# Altium schematic sheet Trigger.SchDoc(TR)
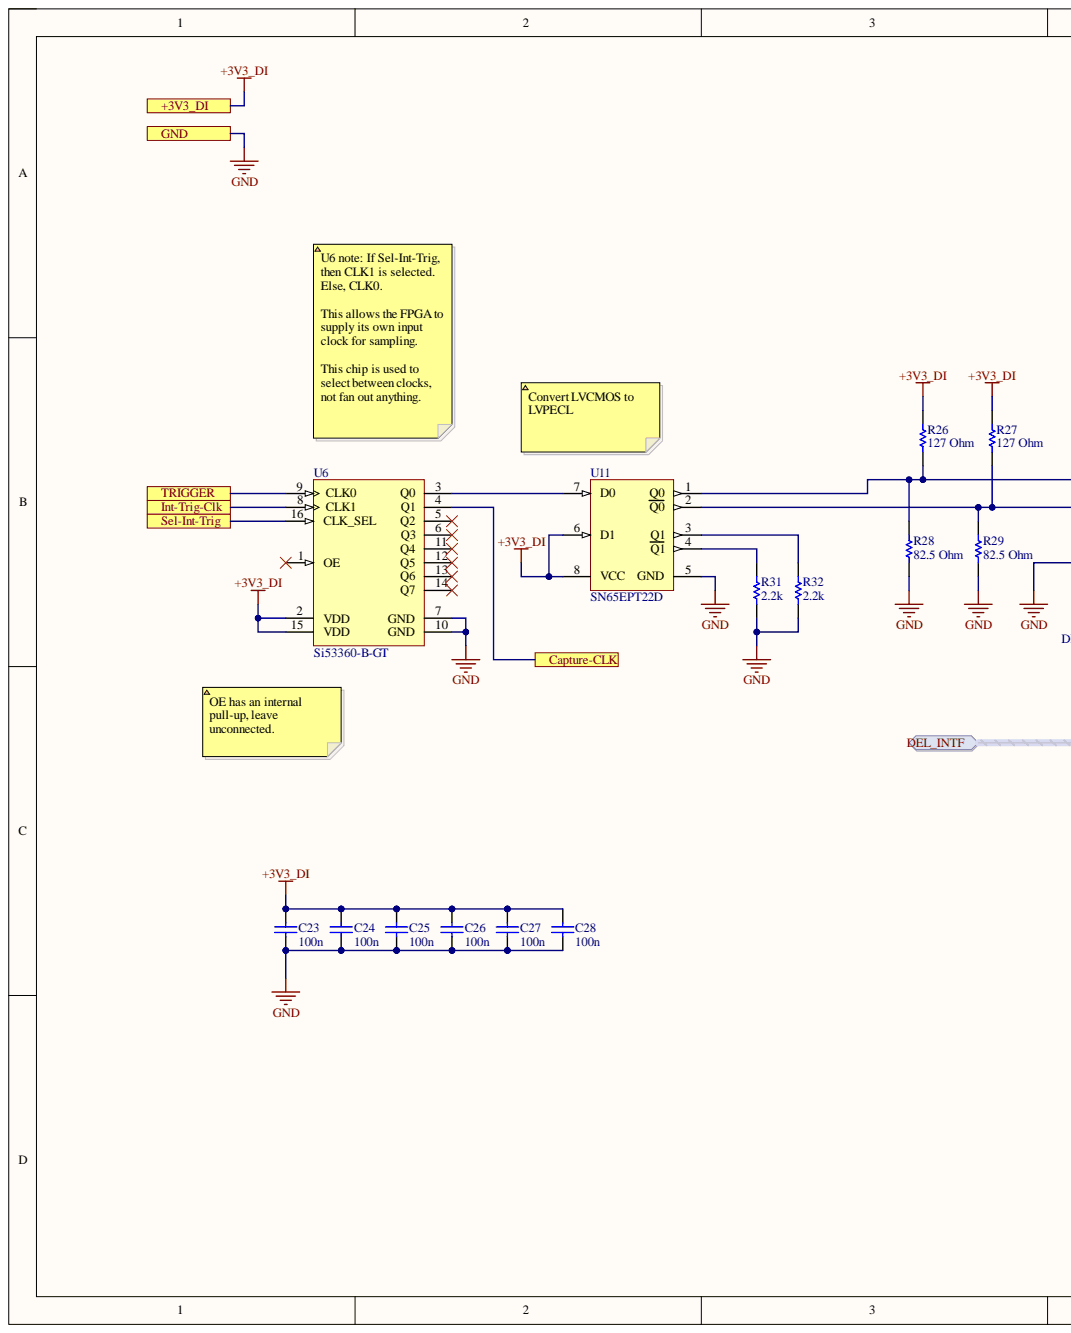
[diagram p0: Trigger.SchDoc, left]
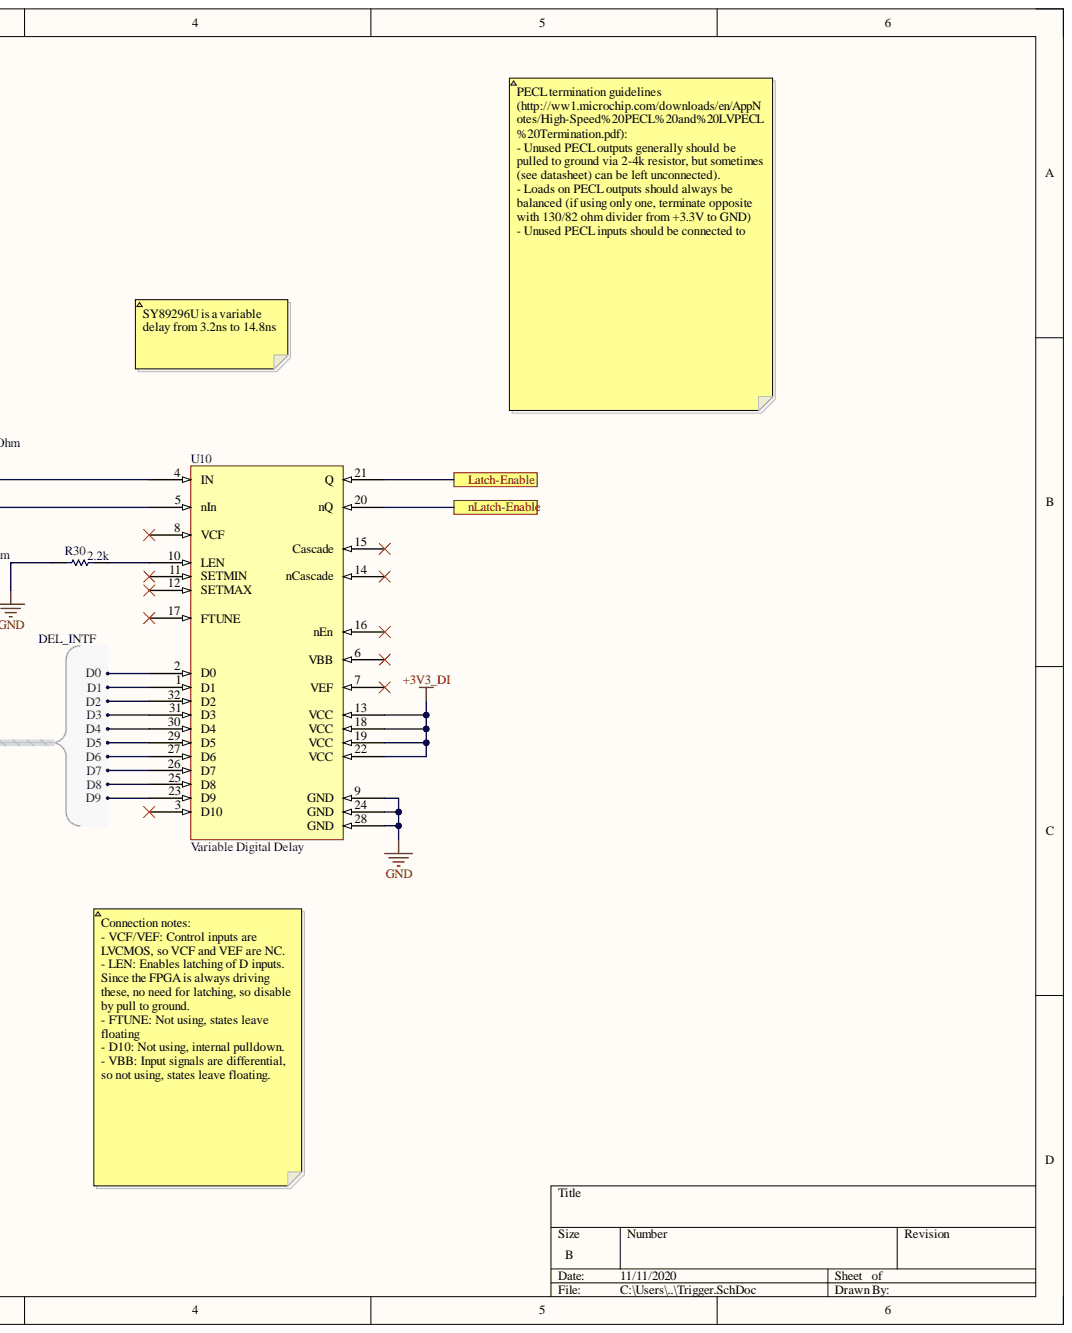
[diagram p1: Trigger.SchDoc, right]

## Parts
C23  value 100n  [CAPC2013X88X50NL20T25]  (hidden comment: C0805C104K5RACTU)
C24  value 100n  [CAPC2013X88X50NL20T25]  (hidden comment: C0805C104K5RACTU)
C25  value 100n  [CAPC2013X88X50NL20T25]  (hidden comment: C0805C104K5RACTU)
C26  value 100n  [CAPC2013X88X50NL20T25]  (hidden comment: C0805C104K5RACTU)
C27  value 100n  [CAPC2013X88X50NL20T25]  (hidden comment: C0805C104K5RACTU)
C28  value 100n  [CAPC2013X88X50NL20T25]  (hidden comment: C0805C104K5RACTU)
R26  127 Ohm  [RESC2013X50X30NL20T20]
R27  127 Ohm  [RESC2013X50X30NL20T20]
R28  82.5 Ohm  [RESC2013X50X30ML20T20]
R29  82.5 Ohm  [RESC2013X50X30ML20T20]
R30  2.2k  [RESC2013X50X30NL20T20]
R31  2.2k  [RESC2013X50X30NL20T20]
R32  2.2k  [RESC2013X50X30NL20T20]
U6  Si53360-B-GT  [SLAB-TSSOP-16_M]
U10  Variable Digital Delay  [CQFP80P1115X1115X120-32N]
U11  SN65EPT22D  [D0008A_M]

## Ports
+3V3_DI, Capture-CLK, DEL_INTF, DEL_INTF.D0, DEL_INTF.D1, DEL_INTF.D2, DEL_INTF.D3, DEL_INTF.D4, DEL_INTF.D5, DEL_INTF.D6, DEL_INTF.D7, DEL_INTF.D8, DEL_INTF.D9, GND, Int-Trig-Clk, Latch-Enable, nLatch-Enable, Sel-Int-Trig, TRIGGER

## Nets
+3V3_DI: C23.2 C24.2 C25.2 C26.2 C27.2 C28.2 R26.2 R27.2 U6.2(VDD) U6.15(VDD) U10.13(VCC) U10.18(VCC) U10.19(VCC) U10.22(VCC) U11.6(D1) U11.8(VCC) ; port +3V3_DI
Capture-CLK: U6.4(Q1) ; port Capture-CLK
GND: C23.1 C24.1 C25.1 C26.1 C27.1 C28.1 R28.1 R29.1 R30.1 R31.2 R32.2 U6.7(GND) U6.10(GND) U10.9(GND) U10.24(GND) U10.28(GND) U11.5(GND) ; port GND
Int-Trig-Clk: U6.8(CLK1) ; port Int-Trig-Clk
Latch-Enable: U10.21(Q) ; port Latch-Enable
nLatch-Enable: U10.20(nQ) ; port nLatch-Enable
Sel-Int-Trig: U6.16(CLK_SEL) ; port Sel-Int-Trig
TRIGGER: U6.9(CLK0) ; port TRIGGER
NetR26_1: R26.1 R28.2 U10.4(IN) U11.1(Q0)
NetR27_1: R27.1 R29.2 U10.5(nIn) U11.2(Q0)
NetR30_2: R30.2 U10.10(LEN)
NetR31_1: R31.1 U11.4(Q1)
NetR32_1: R32.1 U11.3(Q1)
NetU6_1: U6.1(OE)
NetU6_3: U6.3(Q0) U11.7(D0)
NetU6_5: U6.5(Q2)
NetU6_6: U6.6(Q3)
NetU6_11: U6.11(Q4)
NetU6_12: U6.12(Q5)
NetU6_13: U6.13(Q6)
NetU6_14: U6.14(Q7)
NetU10_1: U10.1(D1) ; port DEL_INTF
NetU10_2: U10.2(D0) ; port DEL_INTF
NetU10_3: U10.3(D10)
NetU10_6: U10.6(VBB)
NetU10_7: U10.7(VEF)
NetU10_8: U10.8(VCF)
NetU10_11: U10.11(SETMIN)
NetU10_12: U10.12(SETMAX)
NetU10_14: U10.14(nCascade)
NetU10_15: U10.15(Cascade)
NetU10_16: U10.16(nEn)
NetU10_17: U10.17(FTUNE)
NetU10_23: U10.23(D9) ; port DEL_INTF
NetU10_25: U10.25(D8) ; port DEL_INTF
NetU10_26: U10.26(D7) ; port DEL_INTF
NetU10_27: U10.27(D6) ; port DEL_INTF
NetU10_29: U10.29(D5) ; port DEL_INTF
NetU10_30: U10.30(D4) ; port DEL_INTF
NetU10_31: U10.31(D3) ; port DEL_INTF
NetU10_32: U10.32(D2) ; port DEL_INTF

## Library data of the parts
C23-C28 = CMP-2007-00279-1: Manufacturer: Kemet; Component Kind: Standard; Library Name: Altium Content Vault; Part Number: C0805C104K5RACTU
R26 R27 = CMP-2001-04933-1: Manufacturer: Vishay Dale; Part Number: CRCW0805127RFKEA; Component Kind: Standard; Library Name: Altium Content Vault
R28 R29 = CMP-2001-04138-1: Manufacturer: Vishay Dale; Part Number: CRCW080582R5FKEA; Component Kind: Standard; Library Name: Altium Content Vault
R30-R32 = CMP-2001-03760-1: Manufacturer: Vishay Dale; Part Number: CRCW08052K20FKEA; Component Kind: Standard; Library Name: Altium Content Vault
U6 = CMP-0510-00562-1: 1:8 Low Jitter CMOS Clock Buffer with 2:1 Input Mux, 1 to 200 MHz, -40 to 85 degC, 16-pin SOP; Manufacturer: Silicon Labs; Frequency (MHz): 1 to 200; Output Format: LVCMOS; Sub-Family: Clock Buffers; Code_IPC: J-STD-020C; Code_JEDEC: MO-153; Component Kind: Standard; ComponentLink1Description: Manufacturer URL; ComponentLink1URL: http://www.silabs.com/; ComponentLink2Description: Datasheet; ComponentLink2URL: http://www.silabs.com/Support%20Documents/TechnicalDocs/Si53360.pdf; ComponentLink3Description: Package Specification; ComponentLink3URL: http://www.silabs.com/Support%20Documents/TechnicalDocs/Si53360.pdf; DatasheetVersion: Rev. 0.4; Library Name: Altium Content Vault; Mounting Technology: Surface Mount; PackageDescription: 16-Pin Thin Shrink Small-Outline Package, Body 5 x 4.4 mm, Pitch 0.65 mm; PackageReference: TSSOP-16; PartNumber: Si53360-B-GT
U10 = SY89296U: Component Kind: Standard; Library Name: Local_Parts.SchLib
U11 = CMP-0327-00041-3: 4000 Mbps 3.3 V Dual LVTTL / LVCMOS to Differential LVPECL Buffer, 2 Tx and 2 Rx, -40 to +85 degC, 8-pin SOIC (D), Green (RoHS & no Sb/Br); Manufacturer: Texas Instruments; ICC(Max)(mA): 50; Input Signal: LVTTL, CMOS; No. of Rx: 2; Signaling Rate(Mbps): 4000; SubFamily: PECL PHY; xECL: TRUE; Component Kind: Standard; ComponentLink1Description: Manufacturer URL; ComponentLink1URL: http://www.ti.com/; ComponentLink2Description: Datasheet; ComponentLink2URL: http://www.ti.com/general/docs/lit/getliterature.tsp?genericPartNumber=SN65EPT22&fileType=pdf; ComponentLink3Description: URL Link1; ComponentLink3URL: SamplesURL:http://www.ti.com/product/sn65ept22#samples; DatasheetVersion: SLLS926A; Eco-Plan: Green (RoHS & no Sb/Br); Library Name: Altium Content Vault; Mounting Technology: Surface Mount; No. of Tx: 2; Output Signal: LVPECL; PackageDescription: 8-Pin Plastic Small Outline Integrated Circuit, 4.9 x 3.9 mm, Pitch 1.27 mm Pitch; PackageReference: D0008A; PackageVersion: revM, Jun-2011; PartNumber: SN65EPT22D
